# Altium schematic sheet Keyboard.SchDoc(Keyboard)
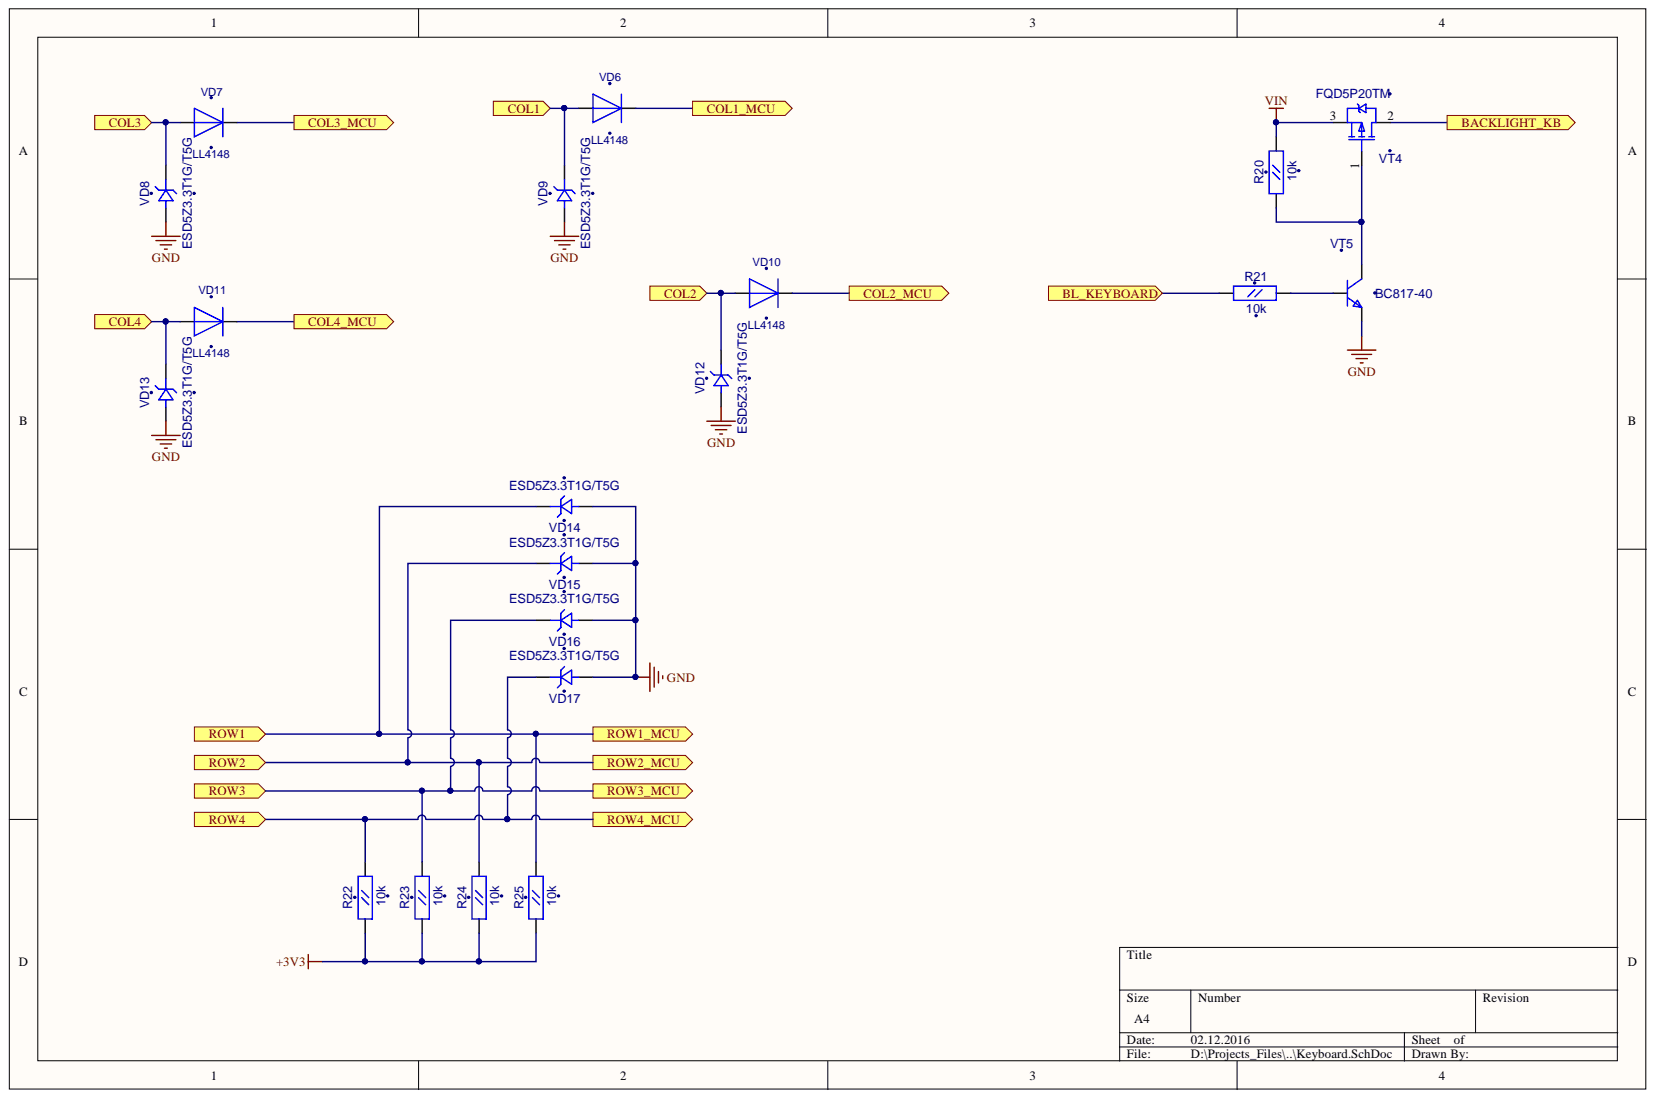
[diagram p0: Keyboard.SchDoc]

## Parts
R20  10k  [RC0603]
R21  10k  [RC0603]
R22  10k  [RC0603]
R23  10k  [RC0603]
R24  10k  [RC0603]
R25  10k  [RC0603]
VD6  LL4148  [SOD-80]
VD7  LL4148  [SOD-80]
VD8  ESD5Z3.3T1G/T5G  [SOD-523]
VD9  ESD5Z3.3T1G/T5G  [SOD-523]
VD10  LL4148  [SOD-80]
VD11  LL4148  [SOD-80]
VD12  ESD5Z3.3T1G/T5G  [SOD-523]
VD13  ESD5Z3.3T1G/T5G  [SOD-523]
VD14  ESD5Z3.3T1G/T5G  [SOD-523]
VD15  ESD5Z3.3T1G/T5G  [SOD-523]
VD16  ESD5Z3.3T1G/T5G  [SOD-523]
VD17  ESD5Z3.3T1G/T5G  [SOD-523]
VT4  FQD5P20TM  [D-PAK(TO-252AA)]
VT5  BC817-40  [SOT-23(123)]

## Ports
BACKLIGHT_KB, BL_KEYBOARD, COL1, COL1_MCU, COL2, COL2_MCU, COL3, COL3_MCU, COL4, COL4_MCU, ROW1, ROW1_MCU, ROW2, ROW2_MCU, ROW3, ROW3_MCU, ROW4, ROW4_MCU

## Nets
+3V3: R22.1 R23.1 R24.1 R25.1
GND: VD8.1 VD9.1 VD12.1 VD13.1 VD14.1 VD15.1 VD16.1 VD17.1 VT5.2
VIN: R20.2 VT4.3
BACKLIGHT_KB: VT4.2 ; port BACKLIGHT_KB
BL_KEYBOARD: R21.1 ; port BL_KEYBOARD
COL1: VD6.1 VD9.2 ; port COL1
COL1_MCU: VD6.2 ; port COL1_MCU
COL2: VD10.1 VD12.2 ; port COL2
COL2_MCU: VD10.2 ; port COL2_MCU
COL3: VD7.1 VD8.2 ; port COL3
COL3_MCU: VD7.2 ; port COL3_MCU
COL4: VD11.1 VD13.2 ; port COL4
COL4_MCU: VD11.2 ; port COL4_MCU
ROW1: R25.2 VD14.2 ; port ROW1, port ROW1_MCU
ROW2: R24.2 VD15.2 ; port ROW2, port ROW2_MCU
ROW3: R23.2 VD16.2 ; port ROW3, port ROW3_MCU
ROW4: R22.2 VD17.2 ; port ROW4, port ROW4_MCU
NetR20_1: R20.1 VT4.1 VT5.3
NetR21_2: R21.2 VT5.1

## Library data of the parts
R20-R25 = RC0603: Component Kind: Standard; Description: RC0603; Value: 10k; Library Name: gprs_modem_2.SchLib
VD8 VD9 VD12-VD17 = ESD5Z: Supressor; Component Kind: Standard; Value: ESD5Z3.3T1G/T5G; Library Name: gprs_modem_2.SchLib
VT4 = IRFR9220: HEXFET Power MOSFET; ComponentField7: Published 8-Jun-2000; OriginalName: IRLML5103; Component Kind: Standard; Value: FQD5P20TM; Library Name: gprs_modem_2.SchLib
VT5 = BC846BLT1: NPN 500ma transistor; ComponentField7: Published 29-Sep-2000; Component Kind: Standard; OriginalName: BC846BLT1; Simulation: BC846BLT1; Value: BC817-40; Library Name: gprs_modem_2.SchLib
VD6 VD7 VD10 VD11 = LL4148: Component Kind: Standard; Description: LL4148; Library Name: gprs_modem_2.SchLib
